# Altium schematic sheet 03_Power_Supply.SchDoc
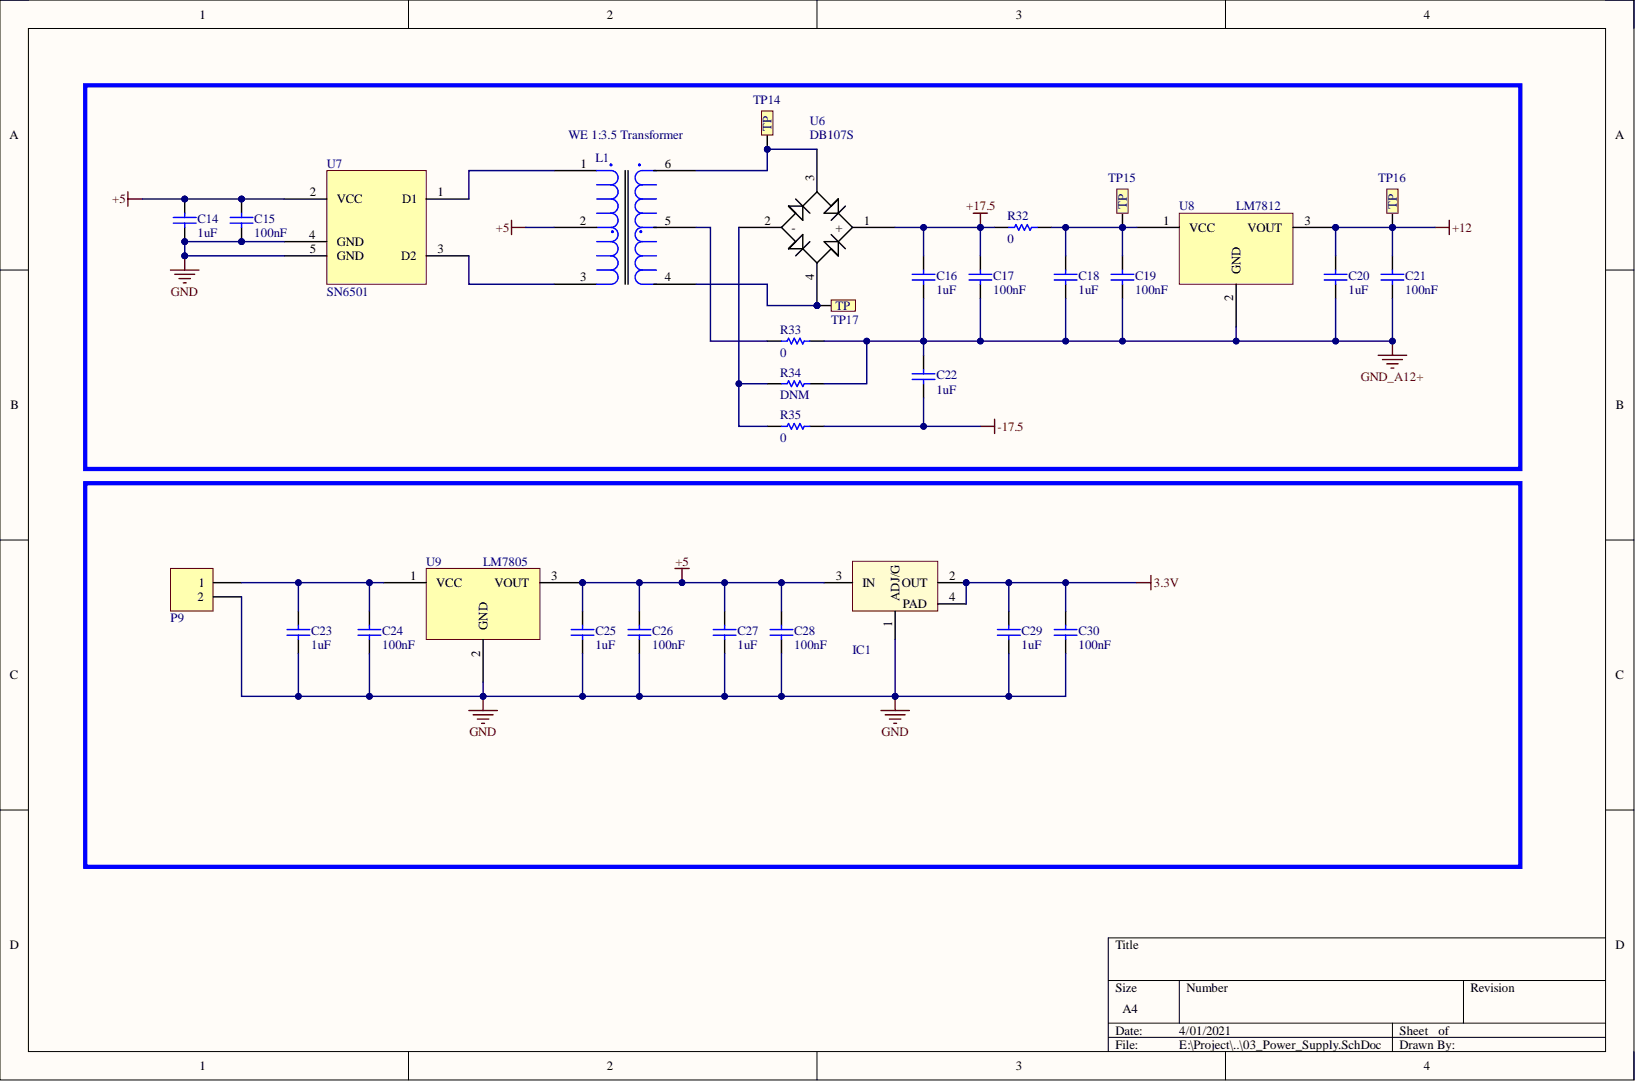
[diagram p0: 03_Power_Supply.SchDoc]

## Parts
C14  value 1uF  [CAP-SM-0805]  (hidden comment: Cap Non-Pol SMD)
C15  value 100nF  [CAP-SM-0805]  (hidden comment: Cap Non-Pol SMD)
C16  value 1uF  [CAP-SM-0805]  (hidden comment: Cap Non-Pol SMD)
C17  value 100nF  [CAP-SM-0805]  (hidden comment: Cap Non-Pol SMD)
C18  value 1uF  [CAP-SM-0805]  (hidden comment: Cap Non-Pol SMD)
C19  value 100nF  [CAP-SM-0805]  (hidden comment: Cap Non-Pol SMD)
C20  value 1uF  [CAP-SM-0805]  (hidden comment: Cap Non-Pol SMD)
C21  value 100nF  [CAP-SM-0805]  (hidden comment: Cap Non-Pol SMD)
C22  value 1uF  [CAP-SM-0805]  (hidden comment: Cap Non-Pol SMD)
C23  value 1uF  [CAP-SM-0805]  (hidden comment: Cap Non-Pol SMD)
C24  value 100nF  [CAP-SM-0805]  (hidden comment: Cap Non-Pol SMD)
C25  value 1uF  [CAP-SM-0805]  (hidden comment: Cap Non-Pol SMD)
C26  value 100nF  [CAP-SM-0805]  (hidden comment: Cap Non-Pol SMD)
C27  value 1uF  [CAP-SM-0805]  (hidden comment: Cap Non-Pol SMD)
C28  value 100nF  [CAP-SM-0805]  (hidden comment: Cap Non-Pol SMD)
C29  value 1uF  [CAP-SM-0805]  (hidden comment: Cap Non-Pol SMD)
C30  value 100nF  [CAP-SM-0805]  (hidden comment: Cap Non-Pol SMD)
IC1  LM1117  [SOT223-4N]  (value not drawn)
L1  WE 1:3.5 Transformer  [750316818]
P9  Domino 2  [DOMINO 2- 5mm]  (value not drawn)
R32  value 0  [RES-SM-1206]  (hidden comment: RESISTOR SMD)
R33  value 0  [RES-SM-1206]  (hidden comment: RESISTOR SMD)
R34  value DNM  [RES-SM-1206]  (hidden comment: RESISTOR SMD)
R35  value 0  [RES-SM-1206]  (hidden comment: RESISTOR SMD)
TP14  Test Point  [TP]  (value not drawn)
TP15  Test Point  [TP]  (value not drawn)
TP16  Test Point  [TP]  (value not drawn)
TP17  Test Point  [TP]  (value not drawn)
U6  DB107S  [SOT510P990X365-4N]
U7  SN6501  [SOT95P280X145-5N]
U8  LM7812  [DPAK228P1014X239-3N]
U9  LM7805  [DPAK228P1014X239-3N]

## Nets
3.3V: C29.2 C30.2 IC1.2(OUT) IC1.4(PAD)
+5: C14.2 C15.2 C25.2 C26.2 C27.2 C28.2 IC1.3(IN) L1.2 U7.2(VCC) U9.3
+12: C20.2 C21.2 TP16.TP1 U8.3
+17.5: C16.2 C17.2 R32.1 U6.1(+)
-17.5: C22.1 R35.1
GND: C14.1 C15.1 C23.1 C24.1 C25.1 C26.1 C27.1 C28.1 C29.1 C30.1 IC1.1(ADJ/G) P9.2 U7.4(GND) U7.5(GND) U9.2
GND_A12+: C16.1 C17.1 C18.1 C19.1 C20.1 C21.1 C22.2 R33.2 R34.2 U8.2
NetC18_2: C18.2 C19.2 R32.2 TP15.TP1 U8.1
NetC23_2: C23.2 C24.2 P9.1 U9.1
NetL1_1: L1.1 U7.1(D1)
NetL1_3: L1.3 U7.3(D2)
NetL1_4: L1.4 TP17.TP1 U6.4
NetL1_5: L1.5 R33.1
NetL1_6: L1.6 TP14.TP1 U6.3
NetR34_1: R34.1 R35.2 U6.2(-)

## Library data of the parts
C14-C30 = Cap Non-Pol SMD: Capacitor; Component Kind: Standard; Library Name: PIF_Library.IntLib
IC1 = LM1117: Available in 1.8V, 2.5V, 2.85V, 3.3V, 5V, and  Adjustable Versions; Value: LM317; Component Kind: Standard; Library Name: PIF_Library.IntLib
L1 = WE 1:3.5 Transformer: Manufacturer: Wurth Elektronik; Component Kind: Standard; ComponentLink1Description: Supplier 1 Link; ComponentLink1URL: https://www.mouser.vn/ProductDetail/Wurth-Elektronik/750316818?qs=%2Fha2pyFaduhwWLO%2FwuaxPfkbn2Vm0sf%2F6JG8uX8izSO8%2FxDOwuQ00g%3D%3D; Manufacturer P/N: 750316818; Supplier 1: Mouser; Supplier 1 P/N: 710-750316818; Library Name: MiclsLib.IntLib
P9 = Domino 2: Domino, 2-Pin; Component Kind: Standard; LatestRevisionDate: 17-Jul-2002; LatestRevisionNote: Re-released for DXP Platform.; Library Name: PIF_Library.IntLib; Publisher: Altium Limited
R32-R35 = RESISTOR SMD: Resistor; Component Kind: Standard; Library Name: PIF_Library.IntLib
U8 U9 = LM78xx: Component Kind: Standard; Library Name: MiclsLib.IntLib
TP14-TP17 = Test Point: Component Kind: Standard; Library Name: PIF_Library.IntLib
U6 = DB107S: Component Kind: Standard; Library Name: MiclsLib.IntLib
U7 = SN6501: Component Kind: Standard; Library Name: MiclsLib.IntLib
